# Altium schematic sheet DigitalLabBoard_LEDs.SchDoc(DigitalLabBoard_LEDs)
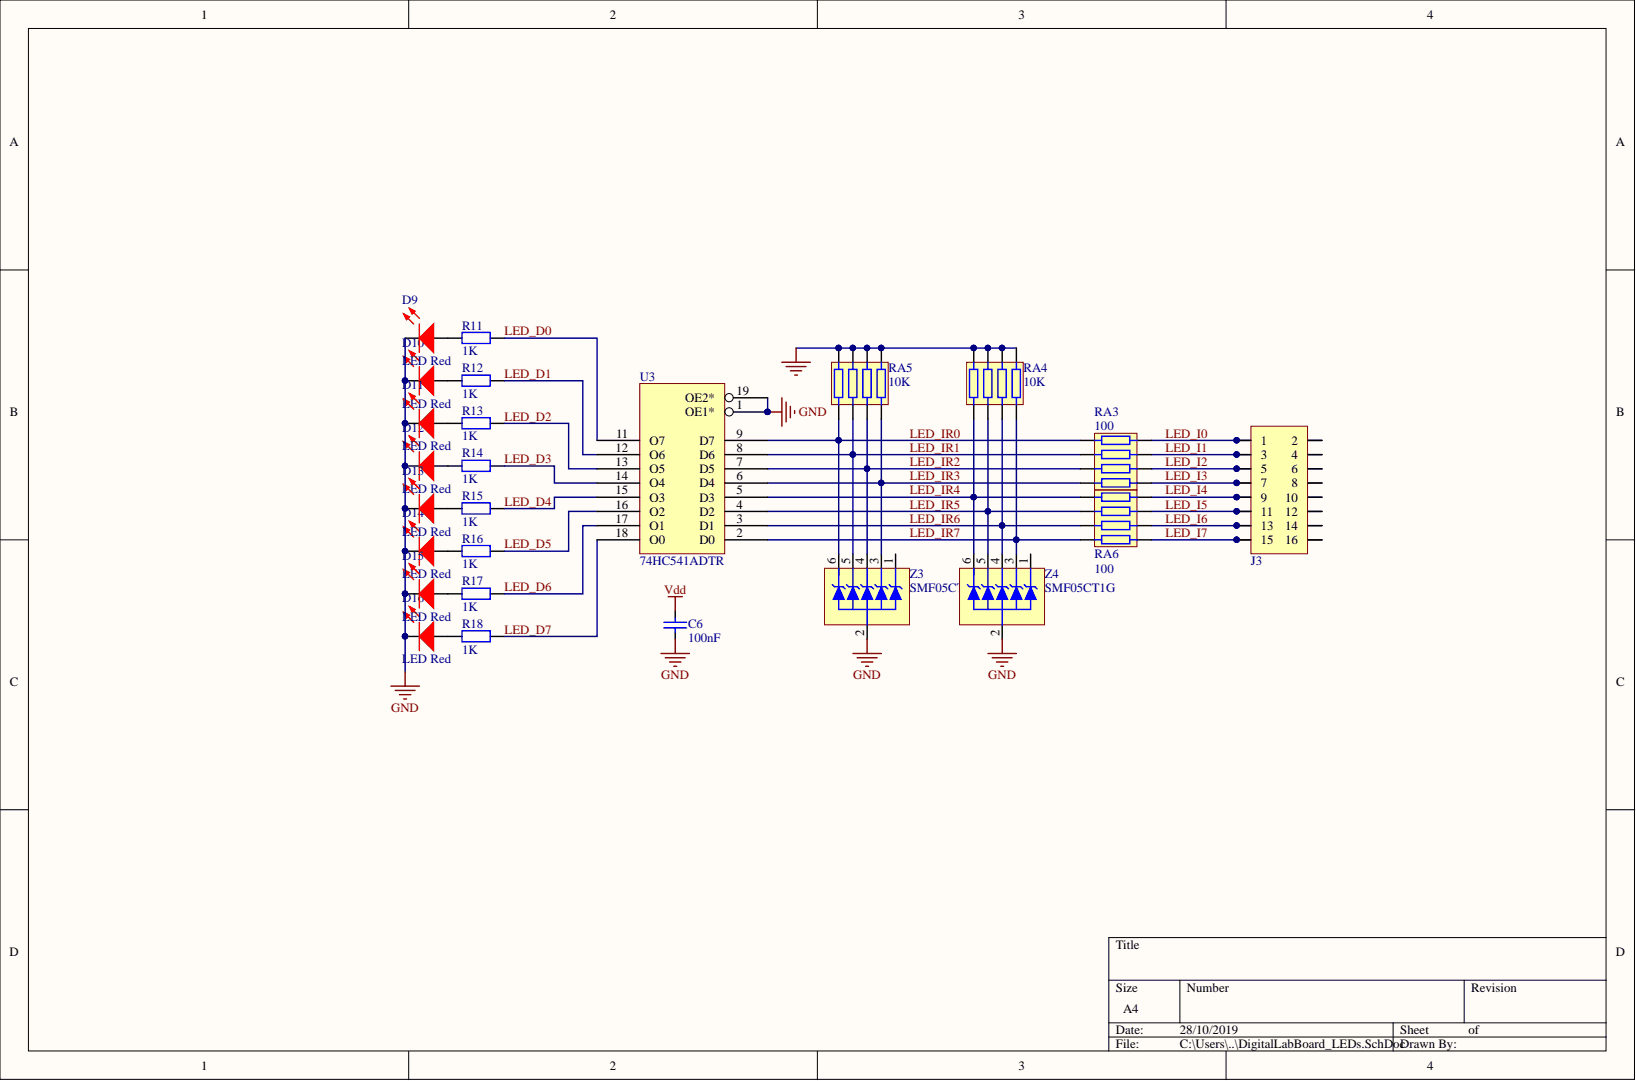
[diagram p0: DigitalLabBoard_LEDs.SchDoc]

## Parts
C6  100nF  [CCNP2012-0805]
D9  LED Red  Class II: LED  [LED1608-0603-RED]
D10  LED Red  Class II: LED  [LED1608-0603-RED]
D11  LED Red  Class II: LED  [LED1608-0603-RED]
D12  LED Red  Class II: LED  [LED1608-0603-RED]
D13  LED Red  Class II: LED  [LED1608-0603-RED]
D14  LED Red  Class II: LED  [LED1608-0603-RED]
D15  LED Red  Class II: LED  [LED1608-0603-RED]
D16  LED Red  Class II: LED  [LED1608-0603-RED]
J3  2x5 Header  [HDR2X8S]  (value not drawn)
R11  1K  [CR1608-0603]
R12  1K  [CR1608-0603]
R13  1K  [CR1608-0603]
R14  1K  [CR1608-0603]
R15  1K  [CR1608-0603]
R16  1K  [CR1608-0603]
R17  1K  [CR1608-0603]
R18  1K  [CR1608-0603]
RA3  100  [CR1206-0603_X4]
RA4  10K  [CR1206-0603_X4]
RA5  10K  [CR1206-0603_X4]
RA6  100  [CR1206-0603_X4]
U3  74HC541ADTR  [TSSOP20]
Z3  SMF05CT1G  [SC70_N]
Z4  SMF05CT1G  [SC70_N]

## Nets
GND: C6.1 D9.K D10.K D11.K D12.K D13.K D14.K D15.K D16.K RA4.5 RA4.6 RA4.7 RA4.8 RA5.5 RA5.6 RA5.7 RA5.8 U3.1(OE1*) U3.10 U3.19(OE2*) Z3.2 Z4.2
Vcc: U3.20
Vdd: C6.2
LED_D0: R11.2 U3.11(O7)
LED_D1: R12.2 U3.12(O6)
LED_D2: R13.2 U3.13(O5)
LED_D3: R14.2 U3.14(O4)
LED_D4: R15.2 U3.15(O3)
LED_D5: R16.2 U3.16(O2)
LED_D6: R17.2 U3.17(O1)
LED_D7: R18.2 U3.18(O0)
LED_I0: J3.1 J3.2 RA3.8
LED_I1: J3.3 J3.4 RA3.7
LED_I2: J3.5 J3.6 RA3.6
LED_I3: J3.7 J3.8 RA3.5
LED_I4: J3.9 J3.10 RA6.8
LED_I5: J3.11 J3.12 RA6.7
LED_I6: J3.13 J3.14 RA6.6
LED_I7: J3.15 J3.16 RA6.5
LED_IR0: RA3.1 RA5.4 U3.9(D7) Z3.6
LED_IR1: RA3.2 RA5.3 U3.8(D6) Z3.5
LED_IR2: RA3.3 RA5.2 U3.7(D5) Z3.4
LED_IR3: RA3.4 RA5.1 U3.6(D4) Z3.3
LED_IR4: RA4.4 RA6.1 U3.5(D3) Z4.6
LED_IR5: RA4.3 RA6.2 U3.4(D2) Z4.5
LED_IR6: RA4.2 RA6.3 U3.3(D1) Z4.4
LED_IR7: RA4.1 RA6.4 U3.2(D0) Z4.3
NetD9_A: D9.A R11.1
NetD10_A: D10.A R12.1
NetD11_A: D11.A R13.1
NetD12_A: D12.A R14.1
NetD13_A: D13.A R15.1
NetD14_A: D14.A R16.1
NetD15_A: D15.A R17.1
NetD16_A: D16.A R18.1

Not connected: Z3 1; Z4 1

## Library data of the parts
C6 = Cap_CCNP_0805: Capacitor non-polar SMT 0805; Manufacturer: Generic Components; Class: Passive; Value: 100nF; SubClass: Capacitor; Simulation: CAP; Signal Integrity: Cap; Published: 08/06/2000; Library Name: MyLibrary.IntLib; Component Kind: Standard; Publisher: Altium Hobart Technology Centre
D9-D16 = LED-0603-Red: LED 0603 Red; Manufacturer: Generic Components; Class I: Optoelectronic; Revision: July-2002: Re-released for DXP Platform.; Published: 8-Jun-2000; Library Name: MySchLib.schlib; Farnell PN: 1581239; Component Kind: Standard; Publisher: Altium Limited
J3 = Header 2x8a: Header 2x8; Library Name: MyLibrary.IntLib; Component Kind: Standard
R11-R18 = Res_CR0603: Resistor SMT 0603; Manufacturer: Generic Components; Class: Passive; Value: 1K; SubClass: Resistor; Simulation: RESISTOR; Signal Integrity: Res; Published: 08/06/2000; Library Name: MyLibrary.IntLib; Component Kind: Standard; Publisher: Altium Hobart Technology Centre
RA3-RA6 = Res_CR0603x4: SMT x4 Resistor Array; Library Name: MyLibrary.IntLib; Component Kind: Standard
  RA3 RA6: Value: 100
  RA4 RA5: Value: 10K
U3 = 74HC541ADTR: Octal 3-State Buffer/Line Driver/LineReceiver; Library Name: MyLibrary.IntLib; Component Kind: Standard
Z3 Z4 = SMF05CT1G: TVS DIODE ARRAY, 100W, 5V, SC-70; Library Name: MySchLib.schlib; Farnell PN: 2533147; Component Kind: Standard
